# Altium schematic sheet SqTeclado.SchDoc(SqTeclado) (continued)
## Library data of the parts (continued)
R5 = ERJ-3EKF1004V: Res Thick Film 0603 1M Ohm 1% 1/10W ±100ppm/°C Molded SMD Punched Carrier T/R; Manufacturer: Panasonic; Max Power Dissipation: 100mW; Value: 1MΩ; Voltage Rating: 75V; Case Code (Imperial): 0603; Case/Package: 0603; Category: Chip SMD Resistors; China RoHS: Non-Compliant; Component Kind: Standard; ComponentLink1Description: https://sigma.octopart.com:443/138766958/technical_drawing/Panasonic-ERJ-3EKF1004V.pdf?src-supplier=Upverter; ComponentLink1URL: https://sigma.octopart.com:443/138766958/technical_drawing/Panasonic-ERJ-3EKF1004V.pdf?src-supplier=Upverter; ComponentLink2Description: https://datasheet.octopart.com:443/ERJ-3EKF1004V-Panasonic-datasheet-82288794.pdf?src-supplier=Future+Electronics; ComponentLink2URL: https://datasheet.octopart.com:443/ERJ-3EKF1004V-Panasonic-datasheet-82288794.pdf?src-supplier=Future+Electronics; ComponentLink3Description: https://datasheet.octopart.com:443/ERJ-3EKF1004V-Panasonic-datasheet-13266541.pdf?src-supplier=RS+(Formerly+Allied+Electronics); ComponentLink3URL: https://datasheet.octopart.com:443/ERJ-3EKF1004V-Panasonic-datasheet-13266541.pdf?src-supplier=RS+(Formerly+Allied+Electronics); ComponentLink4Description: https://datasheet.octopart.com:443/ERJ-3EKF1004V-Panasonic-datasheet-10025800.pdf?src-supplier=element14+APAC; ComponentLink4URL: https://datasheet.octopart.com:443/ERJ-3EKF1004V-Panasonic-datasheet-10025800.pdf?src-supplier=element14+APAC; ComponentLink5Description: https://datasheet.octopart.com:443/ERJ-3EKF1004V-Panasonic-datasheet-8853621.pdf?src-supplier=element14+APAC; ComponentLink5URL: https://datasheet.octopart.com:443/ERJ-3EKF1004V-Panasonic-datasheet-8853621.pdf?src-supplier=element14+APAC; ComponentLink6Description: https://datasheet.octopart.com:443/ERJ-3EKF1004V-Panasonic-datasheet-5313456.pdf?src-supplier=element14+APAC; ComponentLink6URL: https://datasheet.octopart.com:443/ERJ-3EKF1004V-Panasonic-datasheet-5313456.pdf?src-supplier=element14+APAC; ComponentLink7Description: https://datasheet.octopart.com:443/ERJ-3EKF1004V-Panasonic-datasheet-48891056.pdf?src-supplier=Newark; ComponentLink7URL: https://datasheet.octopart.com:443/ERJ-3EKF1004V-Panasonic-datasheet-48891056.pdf?src-supplier=Newark; ComponentLink8Description: https://datasheet.octopart.com:443/ERJ-3EKF1004V-Panasonic-datasheet-168681906.pdf?src-supplier=Newark; ComponentLink8URL: https://datasheet.octopart.com:443/ERJ-3EKF1004V-Panasonic-datasheet-168681906.pdf?src-supplier=Newark; ComponentLink9Description: https://datasheet.octopart.com:443/ERJ-3EKF1004V-Panasonic-datasheet-136608610.pdf?src-supplier=Farnell; ComponentLink9URL: https://datasheet.octopart.com:443/ERJ-3EKF1004V-Panasonic-datasheet-136608610.pdf?src-supplier=Farnell; ComponentLink10Description: https://datasheet.octopart.com:443/ERJ-3EKF1004V-Panasonic-datasheet-154766169.pdf?src-supplier=Master+Electronics; ComponentLink10URL: https://datasheet.octopart.com:443/ERJ-3EKF1004V-Panasonic-datasheet-154766169.pdf?src-supplier=Master+Electronics; ComponentLink11Description: https://datasheet.octopart.com:443/ERJ3EKF1004V-Panasonic-datasheet-138819429.pdf?src-supplier=Burklin+Elektronik; ComponentLink11URL: https://datasheet.octopart.com:443/ERJ3EKF1004V-Panasonic-datasheet-138819429.pdf?src-supplier=Burklin+Elektronik; ComponentLink12Description: https://datasheet.octopart.com/ERJ-3EKF1004V-Panasonic-datasheet-78375410.pdf; ComponentLink12URL: https://datasheet.octopart.com/ERJ-3EKF1004V-Panasonic-datasheet-78375410.pdf; ComponentLink13Description: https://datasheet.octopart.com:443/ERJ-3EKF1004V-Panasonic-datasheet-11542829.pdf?src-supplier=Arrow+Electronics; ComponentLink13URL: https://datasheet.octopart.com:443/ERJ-3EKF1004V-Panasonic-datasheet-11542829.pdf?src-supplier=Arrow+Electronics; ComponentLink14Description: https://datasheet.octopart.com:443/ERJ-3EKF1004V-Panasonic-datasheet-7538305.pdf?src-supplier=Jameco; ComponentLink14URL: https://datasheet.octopart.com:443/ERJ-3EKF1004V-Panasonic-datasheet-7538305.pdf?src-supplier=Jameco; ComponentLink15Description: https://datasheet.octopart.com:443/ERJ-3EKF1004V-Panasonic-datasheet-118602.pdf?src-supplier=DigiKey; ComponentLink15URL: https://datasheet.octopart.com:443/ERJ-3EKF1004V-Panasonic-datasheet-118602.pdf?src-supplier=DigiKey; ComponentLink16Description: https://datasheet.octopart.com:443/ERJ-3EKF1004V-Panasonic-datasheet-41391857.pdf?src-supplier=Electro+Sonic; ComponentLink16URL: https://datasheet.octopart.com:443/ERJ-3EKF1004V-Panasonic-datasheet-41391857.pdf?src-supplier=Electro+Sonic; Composition: Thick Film; Contact Plating: Tin; Depth: 800µm; Features: Automotive AEC-Q200; Height: 450µm; Lead Free: Lead Free; Length: 1.6mm; Lifecycle Status: Production; Manufacturer Part Number: ERJ-3EKF1004V; Max Operating Temperature: 155°C; Military Standard: Not; Min Operating Temperature: -55°C; Mounting Technology: Surface Mount; Number of Terminals: 2; Number of Terminations: 2; Pins: 2; Power Dissipation: 100mW; Power Rating: 100mW; Pricing: 1=0,1, 10=0,034, 25=0,0256, 50=0,021, 100=0,0173, 250=0,01364, 500=0,01158, 1000=0,00995 (USD); Radiation Hardening: No; REACH SVHC: Yes; RoHS: Compliant; Schedule B: 8533210045, 8533210045|8533210045|8533210045|8533210045|8533210045|8533210045, 8533210045|8533210045|8533210045|8533210045|8533210045|8533210045|8533210045, 8533210045|8533210045|8533210045|8533210045|8533210045|8533210045|8533210045|8533210045|8533210045; Stock: 52419; Supplier: DigiKey; Supplier Part Number: P1.00MHCT-ND; Temperature Coefficient: 100ppm/°C; Termination: SMD/SMT; Tolerance: 1%; Voltage Rating (DC): 75V; Width: 800µm; Working Voltage: 75V; Library Name: Esqlib.SchLib
R7 = M55342K06B1E50RS3: Fixed Resistor, Metal Glaze/thick Film, 0.15W, 1500ohm, 50V, 1% +/-Tol, 100ppm/Cel, Surface Mount, 0705; Manufacturer: Vishay; Max Power Dissipation: 150mW; Temperature Coefficient: 100ppm/°C; Value: 1.5kΩ; Case Code (Imperial): 0805; Case Code (Metric): 2012; Case/Package: 0705; Category: Chip SMD Resistors; China RoHS: Non-Compliant; Component Kind: Standard; ComponentLink1Description: https://datasheet.octopart.com:443/M55342K06B1E50RS3-Vishay-datasheet-159614010.pdf?src-supplier=IHS; ComponentLink1URL: https://datasheet.octopart.com:443/M55342K06B1E50RS3-Vishay-datasheet-159614010.pdf?src-supplier=IHS; ComponentLink2Description: http://sigma.octopart.com:80/rohs_statement/Vishay-company-15.pdf?src-supplier=Compliance+Statements; ComponentLink2URL: http://sigma.octopart.com:80/rohs_statement/Vishay-company-15.pdf?src-supplier=Compliance+Statements; Composition: Thick Film; Contact Plating: Lead, Tin; Depth: 1.27mm; Failure Rate: 0.01%; Features: Military; Height: 510µm; Length: 2.032mm; Lifecycle Status: Production; Manufacturer Part Number: M55342K06B1E50RS3; Max Operating Temperature: 150°C; Military Standard: MIL-PRF-55342; Min Operating Temperature: -65°C; Mounting Technology: Surface Mount; Number of Terminals: 2; Number of Terminations: 2; Packaging: Digi-Reel®; Power Dissipation: 150mW; Pricing: 1=1,42, 10=0,838, 25=0,7528, 50=0,74, 100=0,7082, 250=0,66168, 500=0,63208 (USD); Radiation Hardening: No; REACH SVHC: No; RoHS: Non-Compliant; Schedule B: 8533210045, 8533210045|8533210045|8533210045|8533210045|8533210045; Stock: 759; Supplier: DigiKey; Supplier Part Number: 541-M55342K06B1E50RS3CT-ND; Tolerance: 1%; Width: 1.27mm; Working Voltage: 50V; Library Name: Esqlib.SchLib
U1 = USB4110-GF-A: USB Connector, 16 Contact(s), Male, Right Angle, Surface Mount Terminal, Locking, Receptacle; Manufacturer: Global Connector Technology; Contact Resistance: 50mΩ; Dielectric Material: Polyethylene; Insulation Resistance: 100MΩ; Number of Contacts: 16; Number of Ports: 1; USB Standard: USB 2.0; Voltage Rating: 20V; Category: USB Connectors; China RoHS: Compliant; Component Kind: Standard; ComponentLink1Description: https://datasheet.octopart.com:443/USB4110-GF-A-Global-Connector-Technology-datasheet-166929324.pdf?src-supplier=SOS+electronic; ComponentLink1URL: https://datasheet.octopart.com:443/USB4110-GF-A-Global-Connector-Technology-datasheet-166929324.pdf?src-supplier=SOS+electronic; ComponentLink2Description: https://datasheet.octopart.com:443/USB4110-GF-A-Global-Connector-Technology-datasheet-137417970.pdf?src-supplier=Upverter; ComponentLink2URL: https://datasheet.octopart.com:443/USB4110-GF-A-Global-Connector-Technology-datasheet-137417970.pdf?src-supplier=Upverter; ComponentLink3Description: https://datasheet.octopart.com:443/USB4110-GF-A-Global-Connector-Technology-datasheet-179886649.pdf?src-supplier=TME; ComponentLink3URL: https://datasheet.octopart.com:443/USB4110-GF-A-Global-Connector-Technology-datasheet-179886649.pdf?src-supplier=TME; ComponentLink4Description: https://datasheet.octopart.com:443/USB4110-GF-A-GCT-datasheet-188869077.pdf?src-supplier=TME; ComponentLink4URL: https://datasheet.octopart.com:443/USB4110-GF-A-GCT-datasheet-188869077.pdf?src-supplier=TME; ComponentLink5Description: https://datasheet.octopart.com:443/USB4110-GF-A-GCT-datasheet-166933377.pdf?src-supplier=TME; ComponentLink5URL: https://datasheet.octopart.com:443/USB4110-GF-A-GCT-datasheet-166933377.pdf?src-supplier=TME; Contact Gender: Male; Contact Material: Gold, Nickel; Gender: Receptacle; Height: 3.46mm; Lifecycle Status: Production; Manufacturer Part Number: USB4110-GF-A; Max Operating Temperature: 85°C; Min Operating Temperature: -40°C; Number of Rows: 1; Orientation: Horizontal; Pins: 16; Pricing: 1=1,24, 10=1,049, 25=0,9836, 50=0,9364, 100=0,8916, 250=0,83576, 500=0,79584 (USD); REACH SVHC: Yes; RoHS: Compliant; Shell Finish: Nickel; Shell Material: Stainless Steel; Stock: 41559; Supplier: DigiKey; Supplier Part Number: 2073-USB4110-GF-A-1-ND; Terminal Pitch: 500µm; Library Name: Esqlib.SchLib
U2-U4 U6-U17 = 4958: Kailh Switch Sockets For Mx-Compatible Mechanical Keys - 20 Pack |Adafruit 4958; Manufacturer: Adafruit Industries; Category: Switch Accessories; Component Kind: Standard; Manufacturer Part Number: 4958; Pricing: 1=4,95 (USD); Stock: 66; Supplier: DigiKey; Supplier Part Number: 1528-4958-ND; Library Name: Esqlib.SchLib
U5 = STM32F103C8T6: MCU 32-bit STM32F1 ARM Cortex M3 RISC 64KB Flash 2.5V/3.3V 48-Pin LQFP Tray; Manufacturer: STMicroelectronics; Manufacturer Package Identifier: ai14911d; Address Bus Width: 32b; Clock Frequency: 72MHz; Clock Rate: 16MHz; Density: 512kb; EEPROM Memory Size: 0B; Interface: CAN, USB; Max Power Dissipation: 363mW; Max Supply Current: 50mA; Max Supply Voltage: 3.6V; Min Supply Voltage: 2V; Nominal Supply Current: 1.7µA; Number of A/D Converters: 2; Number of ADC Channels: 10; Number of Bits: 32; Number of I/Os: 37; Number of Interrupts: 16; Number of Programmable I/O: 48; Number of PWM Channels: 1; Number of Timers/Counters: 4; Number of USART Channels: 3; Operating Supply Voltage: 3.3V; Oscillator Type: Internal; Peripherals: DMA, I2C, POR, PWM, Temp Sensor, WDT; RAM Size: 20kB; Watchdog Timer: Yes; Case/Package: LQFP; Category: Microcontrollers; China RoHS: Compliant; Component Kind: Standard; ComponentLink1Description: https://datasheet.octopart.com:443/STM32F103C8T6-STMicroelectronics-datasheet-48026265.pdf?src-supplier=ICpick; ComponentLink1URL: https://datasheet.octopart.com:443/STM32F103C8T6-STMicroelectronics-datasheet-48026265.pdf?src-supplier=ICpick; ComponentLink2Description: https://datasheet.octopart.com:443/STM32F103C8T6-STMicroelectronics-datasheet-10330614.pdf?src-supplier=Newark; ComponentLink2URL: https://datasheet.octopart.com:443/STM32F103C8T6-STMicroelectronics-datasheet-10330614.pdf?src-supplier=Newark; ComponentLink3Description: https://datasheet.octopart.com:443/STM32F103C8T6-STMicroelectronics-datasheet-16839800.pdf?src-supplier=Newark; ComponentLink3URL: https://datasheet.octopart.com:443/STM32F103C8T6-STMicroelectronics-datasheet-16839800.pdf?src-supplier=Newark; ComponentLink4Description: https://datasheet.octopart.com:443/STM32F103C8T6-STMicroelectronics-datasheet-14363502.pdf?src-supplier=Farnell; ComponentLink4URL: https://datasheet.octopart.com:443/STM32F103C8T6-STMicroelectronics-datasheet-14363502.pdf?src-supplier=Farnell; ComponentLink5Description: https://datasheet.octopart.com:443/STM32F103C8T6-STMicroelectronics-datasheet-500013.pdf?src-supplier=Farnell; ComponentLink5URL: https://datasheet.octopart.com:443/STM32F103C8T6-STMicroelectronics-datasheet-500013.pdf?src-supplier=Farnell; ComponentLink6Description: https://datasheet.octopart.com:443/STM32F103C8T6-STMicroelectronics-datasheet-8365036.pdf?src-supplier=Farnell; ComponentLink6URL: https://datasheet.octopart.com:443/STM32F103C8T6-STMicroelectronics-datasheet-8365036.pdf?src-supplier=Farnell; ComponentLink7Description: https://datasheet.octopart.com:443/STM32F103C8T6-STMicroelectronics-datasheet-6396519.pdf?src-supplier=Verical; ComponentLink7URL: https://datasheet.octopart.com:443/STM32F103C8T6-STMicroelectronics-datasheet-6396519.pdf?src-supplier=Verical; ComponentLink8Description: https://datasheet.octopart.com:443/STM32F103C8T6-STMicroelectronics-datasheet-21407612.pdf?src-supplier=element14+APAC; ComponentLink8URL: https://datasheet.octopart.com:443/STM32F103C8T6-STMicroelectronics-datasheet-21407612.pdf?src-supplier=element14+APAC; ComponentLink9Description: https://datasheet.octopart.com:443/STM32F103C8T6-STMicroelectronics-datasheet-8700640.pdf?src-supplier=element14; ComponentLink9URL: https://datasheet.octopart.com:443/STM32F103C8T6-STMicroelectronics-datasheet-8700640.pdf?src-supplier=element14; ComponentLink10Description: https://datasheet.octopart.com:443/STM32F103C8T6-STMicroelectronics-datasheet-177520228.pdf?src-supplier=Burklin+Elektronik; ComponentLink10URL: https://datasheet.octopart.com:443/STM32F103C8T6-STMicroelectronics-datasheet-177520228.pdf?src-supplier=Burklin+Elektronik; ComponentLink11Description: https://datasheet.octopart.com:443/STM32F103C8T6-STMicroelectronics-datasheet-165583531.pdf?src-supplier=SOS+electronic; ComponentLink11URL: https://datasheet.octopart.com:443/STM32F103C8T6-STMicroelectronics-datasheet-165583531.pdf?src-supplier=SOS+electronic; ComponentLink12Description: https://datasheet.octopart.com:443/STM32F103C8T6-STMicroelectronics-datasheet-14106722.pdf?src-supplier=iiiC; ComponentLink12URL: https://datasheet.octopart.com:443/STM32F103C8T6-STMicroelectronics-datasheet-14106722.pdf?src-supplier=iiiC; ComponentLink13Description: https://datasheet.octopart.com:443/STM32F103C8T6-STMicroelectronics-datasheet-17594274.pdf?src-supplier=TME; ComponentLink13URL: https://datasheet.octopart.com:443/STM32F103C8T6-STMicroelectronics-datasheet-17594274.pdf?src-supplier=TME; ComponentLink14Description: https://datasheet.octopart.com:443/STM32F103C8T6-STMicroelectronics-datasheet-148251.pdf?src-supplier=Nu+Horizons; ComponentLink14URL: https://datasheet.octopart.com:443/STM32F103C8T6-STMicroelectronics-datasheet-148251.pdf?src-supplier=Nu+Horizons; Core Architecture: ARM; Data Bus Width: 32b; Flash Memory Size: 64kB; Frequency: 72MHz; Height: 1.45mm; Height - Seated (Max): 1.6mm; Lead Free: Lead Free; Length: 7mm; Lifecycle Status: Production; Manufacturer Part Number: STM32F103C8T6; Max Operating Temperature: 85°C; Memory Format: FLASH; Memory Size: 64kB; Min Operating Temperature: -40°C; Mounting Technology: Surface Mount; Number of Channels: 10; Number of I2C Channels: 2; Number of SPI Channels: 2; Number of Terminals: 48; Pins: 48; Pricing: 1=6,08, 10=4,662, 25=4,3084, 100=3,9192, 250=3,73372, 500=3,6219, 1000=3,5299, 2500=3,43276 (USD); Radiation Hardening: No; REACH SVHC: Yes; RoHS: Compliant; Schedule B: 8542310000; Stock: 5599; Supplier: DigiKey; Supplier Part Number: 497-6063-ND; Terminal Pitch: 500µm; Termination: SMD/SMT; Width: 7mm; Library Name: Esqlib.SchLib
U18 = USBLC6-2SC6: USBLC6 Series 2 Line 6 V Uni / Bi-Directional ESD Protection - SOT-23-6; Manufacturer: STMicroelectronics; Breakdown Voltage: 6V; Clamping Voltage: 17V; Data Rate: 480Mbps; Direction: Unidirectional; Element Configuration: Dual; ESD Protection: Yes; Forward Voltage: 1.1V; Leakage Current: 150nA; Max Junction Temperature (Tj): 125°C; Max Repetitive Reverse Voltage (Vrrm): 5.25V; Number of Bidirectional Channels: 2; Number of Elements: 1; Peak Pulse Current: 5A; Test Current: 1mA; Value: 2.5pF; Case/Package: SOT-23; Category: TVS Diodes; China RoHS: Compliant; Component Kind: Standard; ComponentLink1Description: https://datasheet.octopart.com:443/USBLC6-2SC6-STMicroelectronics-datasheet-10412581.pdf?src-supplier=Newark; ComponentLink1URL: https://datasheet.octopart.com:443/USBLC6-2SC6-STMicroelectronics-datasheet-10412581.pdf?src-supplier=Newark; ComponentLink2Description: https://datasheet.octopart.com:443/USBLC6-2SC6-STMicroelectronics-datasheet-181961833.pdf?src-supplier=Newark; ComponentLink2URL: https://datasheet.octopart.com:443/USBLC6-2SC6-STMicroelectronics-datasheet-181961833.pdf?src-supplier=Newark; ComponentLink3Description: https://datasheet.octopart.com:443/USBLC6-2SC6-STMicroelectronics-datasheet-525477.pdf?src-supplier=Factory+Futures; ComponentLink3URL: https://datasheet.octopart.com:443/USBLC6-2SC6-STMicroelectronics-datasheet-525477.pdf?src-supplier=Factory+Futures; ComponentLink4Description: https://datasheet.octopart.com:443/USBLC6-2SC6-STMicroelectronics-datasheet-82998616.pdf?src-supplier=Farnell; ComponentLink4URL: https://datasheet.octopart.com:443/USBLC6-2SC6-STMicroelectronics-datasheet-82998616.pdf?src-supplier=Farnell; ComponentLink5Description: https://datasheet.octopart.com:443/USBLC6-2SC6-STMicroelectronics-datasheet-46285.pdf?src-supplier=Farnell; ComponentLink5URL: https://datasheet.octopart.com:443/USBLC6-2SC6-STMicroelectronics-datasheet-46285.pdf?src-supplier=Farnell; ComponentLink6Description: https://datasheet.octopart.com:443/USBLC6-2SC6-STMicroelectronics-datasheet-14050643.pdf?src-supplier=iiiC; ComponentLink6URL: https://datasheet.octopart.com:443/USBLC6-2SC6-STMicroelectronics-datasheet-14050643.pdf?src-supplier=iiiC; ComponentLink7Description: https://datasheet.octopart.com:443/USBLC6-2SC6-STMicroelectronics-datasheet-10521292.pdf?src-supplier=Jameco; ComponentLink7URL: https://datasheet.octopart.com:443/USBLC6-2SC6-STMicroelectronics-datasheet-10521292.pdf?src-supplier=Jameco; Contact Plating: Tin; Depth: 1.75mm; Height: 1.45mm; Lead Free: Lead Free; Length: 3.05mm; Lifecycle Status: Production; Manufacturer Part Number: USBLC6-2SC6; Max Breakdown Voltage: 6V; Max Operating Temperature: 125°C; Max Reverse Leakage Current: 150nA; Min Breakdown Voltage: 6V; Min Operating Temperature: -40°C; Mounting Technology: Surface Mount; Number of Channels: 2; Number of Terminals: 6; Operating Supply Voltage: 1.1V; Peak Reverse Current: 150nA; Pins: 6; Polarity: Unidirectional; Power Line Protection: Yes; Pricing: 1=0,36, 10=0,243, 100=0,163, 500=0,127, 1000=0,114 (USD); Radiation Hardening: No; REACH SVHC: Yes; Reverse Breakdown Voltage: 6V; RoHS: Compliant; Schedule B: 8536300000, 8536300000|8536300000|8536300000|8536300000|8536300000|8536300000|8536300000|8536300000|8536300000|8536300000|8536300000|8536300000|8536300000|8536300000|8536300000|8536300000|8536300000|8536300000|8536300000|8536300000|8536300000|8536300000|8536300000|85; Stock: 161946; Supplier: DigiKey; Supplier Part Number: 497-5235-1-ND; Termination: SMD/SMT; Width: 1.75mm; Working Voltage: 5V; Library Name: Esqlib.SchLib
U19 = RT9193-33GB: RT9193 Series 300 mA 3.3 V Ultra-Low Noise Ultra-Fast CMOS LDO Regulator-SC-70-5; Manufacturer: Richtek; Dropout Voltage: 220mV; Max Junction Temperature (Tj): 125°C; Max Supply Voltage: 5.5V; Min Current Limit: 360mA; Min Input Voltage: 4.3V; Nominal Output Voltage: 3.3V; Number of Circuits: 1; Output Current: 300mA; Voltage Tolerance: 2%; Case/Package: SOT-23-5; Category: LDO Voltage Regulators; Component Kind: Standard; ComponentLink1Description: https://datasheet.octopart.com:443/RT9193-33GB-Richtek-datasheet-181204355.pdf?src-supplier=ODG+(Origin+Data+Global); ComponentLink1URL: https://datasheet.octopart.com:443/RT9193-33GB-Richtek-datasheet-181204355.pdf?src-supplier=ODG+(Origin+Data+Global); ComponentLink2Description: https://datasheet.octopart.com:443/RT9193-33GB-Richtek-datasheet-103962855.pdf?src-supplier=Augswan; ComponentLink2URL: https://datasheet.octopart.com:443/RT9193-33GB-Richtek-datasheet-103962855.pdf?src-supplier=Augswan; ComponentLink3Description: https://datasheet.octopart.com:443/RT9193-33GB-Richtek-datasheet-140440429.pdf?src-supplier=LKR; ComponentLink3URL: https://datasheet.octopart.com:443/RT9193-33GB-Richtek-datasheet-140440429.pdf?src-supplier=LKR; ComponentLink4Description: https://datasheet.octopart.com:443/RT9193-33GB-Richtek-datasheet-21631297.pdf?src-supplier=element14+APAC; ComponentLink4URL: https://datasheet.octopart.com:443/RT9193-33GB-Richtek-datasheet-21631297.pdf?src-supplier=element14+APAC; ComponentLink5Description: https://datasheet.octopart.com:443/RT9193-33GB-Richtek-datasheet-21405099.pdf?src-supplier=Future+Electronics; ComponentLink5URL: https://datasheet.octopart.com:443/RT9193-33GB-Richtek-datasheet-21405099.pdf?src-supplier=Future+Electronics; ComponentLink6Description: https://datasheet.octopart.com:443/RT9193-33GB-Richtek-datasheet-12517944.pdf?src-supplier=Future+Electronics; ComponentLink6URL: https://datasheet.octopart.com:443/RT9193-33GB-Richtek-datasheet-12517944.pdf?src-supplier=Future+Electronics; ComponentLink7Description: https://datasheet.octopart.com:443/RT9193-33GB-Richtek-datasheet-14171959.pdf?src-supplier=iiiC; ComponentLink7URL: https://datasheet.octopart.com:443/RT9193-33GB-Richtek-datasheet-14171959.pdf?src-supplier=iiiC; Height - Seated (Max): 1.295mm; Lead Free: Lead Free; Length: 2.89mm; Lifecycle Status: Production; Manufacturer Part Number: RT9193-33GB; Max Operating Temperature: 85°C; Min Operating Temperature: -40°C; Mounting Technology: Surface Mount; Number of Outputs: 1; Number of Regulators: 1; Number of Terminals: 5; Output Voltage: 3.3V; Pins: 5; Pricing: 1=0,41, 10=0,283, 25=0,2516, 100=0,2178, 250=0,20156, 500=0,19182, 1000=0,18377 (USD); REACH SVHC: Yes; RoHS: Compliant; Stock: 9476; Supplier: DigiKey; Supplier Part Number: 1028-1014-1-ND; Terminal Pitch: 950µm; Width: 1.6mm; Library Name: Esqlib.SchLib
X1-X15 = MX1A-C1NN: Switch, Key, Spst-no, Keyboard, Low Profile, 10MA, 12VDC, Soft Tactile, Fp Mnt, Std Force; Manufacturer: Cherry; Actuator Length: 3.6mm; Actuator Material: Polyethylene, Thermoplastic; Contact Resistance: 200mΩ; Contact Voltage Rating (AC): 12V; Dielectric Material: Thermoplastic; Electrical Life: 20Mcycles; Insulation Resistance: 100MΩ; Operating Force: 589.4mN; Row Spacing: 2.54mm; Switch Function: Off-Mom; Throw Configuration: SPST; Category: Pushbutton Switches; Component Kind: Standard; ComponentLink1Description: https://datasheet.octopart.com:443/MX1A-C1NN-Cherry-datasheet-160429.pdf?src-supplier=Electro+Sonic; ComponentLink1URL: https://datasheet.octopart.com:443/MX1A-C1NN-Cherry-datasheet-160429.pdf?src-supplier=Electro+Sonic; ComponentLink2Description: https://datasheet.octopart.com:443/MX1A-C1NN-Cherry-datasheet-11735291.pdf?src-supplier=Electro+Sonic; ComponentLink2URL: https://datasheet.octopart.com:443/MX1A-C1NN-Cherry-datasheet-11735291.pdf?src-supplier=Electro+Sonic; ComponentLink3Description: https://datasheet.octopart.com:443/MX1A-C1NN-Cherry-datasheet-130429.pdf?src-supplier=Newark; ComponentLink3URL: https://datasheet.octopart.com:443/MX1A-C1NN-Cherry-datasheet-130429.pdf?src-supplier=Newark; ComponentLink4Description: https://datasheet.octopart.com:443/MX1A-C1NN-Cherry-datasheet-101570275.pdf?src-supplier=Newark; ComponentLink4URL: https://datasheet.octopart.com:443/MX1A-C1NN-Cherry-datasheet-101570275.pdf?src-supplier=Newark; ComponentLink5Description: https://datasheet.octopart.com:443/MX1A-C1NN-Cherry-datasheet-5391353.pdf?src-supplier=Newark; ComponentLink5URL: https://datasheet.octopart.com:443/MX1A-C1NN-Cherry-datasheet-5391353.pdf?src-supplier=Newark; ComponentLink6Description: https://datasheet.octopart.com:443/MX1AC1NN-Cherry-datasheet-137317204.pdf?src-supplier=Sager+Electronics; ComponentLink6URL: https://datasheet.octopart.com:443/MX1AC1NN-Cherry-datasheet-137317204.pdf?src-supplier=Sager+Electronics; Contact Current Rating: 10mA; Contact Material: Gold, Silver; Contact Plating: Gold; Current Rating: 10mA; Housing Material: Thermoplastic; Lead Free: Lead Free; Length: 15.5956mm; Manufacturer Part Number: MX1A-C1NN; Max Current Rating: 10mA; Max Operating Temperature: 70°C; Max Voltage Rating (AC): 12V; Max Voltage Rating (DC): 12V; Mechanical Life: 20Mcycles; Min Operating Temperature: -10°C; Mounting Technology: Front, Panel, Through Hole; Number of Terminals: 2; Packaging: Bulk; Pricing: 1=1,25 (USD); REACH SVHC: Yes; RoHS: Compliant; Stock: 664; Supplier: DigiKey; Supplier Part Number: CH198-ND; Terminal Pitch: 6.35mm; Termination: PC Pin; Voltage Rating (AC): 12V; Voltage Rating (DC): 12V; Width: 15.6mm; Library Name: Esqlib.SchLib
Y1 = ECS-80-20-5PXDU-TR: Crystal 8MHz ±30ppm (Tol) ±100ppm (Stability) 20pF FUND 60Ohm 2-Pin SMD T/R; Manufacturer: ECS International; ESR: 60Ω; Frequency Tolerance: 0.003%; Category: Crystals; China RoHS: Compliant; Component Kind: Standard; ComponentLink1Description: https://datasheet.octopart.com:443/ECS-80-20-5PXDU-TR-ECS-International-datasheet-17018830.pdf?src-supplier=IHS; ComponentLink1URL: https://datasheet.octopart.com:443/ECS-80-20-5PXDU-TR-ECS-International-datasheet-17018830.pdf?src-supplier=IHS; ComponentLink2Description: https://datasheet.octopart.com:443/ECS-80-20-5PXDU-TR-ECS-International-datasheet-166511516.pdf?src-supplier=Master+Electronics; ComponentLink2URL: https://datasheet.octopart.com:443/ECS-80-20-5PXDU-TR-ECS-International-datasheet-166511516.pdf?src-supplier=Master+Electronics; ComponentLink3Description: https://datasheet.octopart.com:443/ECS-80-20-5PXDU-TR-ECS-International-datasheet-14433430.pdf?src-supplier=Factory+Futures; ComponentLink3URL: https://datasheet.octopart.com:443/ECS-80-20-5PXDU-TR-ECS-International-datasheet-14433430.pdf?src-supplier=Factory+Futures; ComponentLink4Description: https://datasheet.octopart.com:443/ECS-80-20-5PXDU-TR-ECS-International-datasheet-17018642.pdf?src-supplier=Future+Electronics; ComponentLink4URL: https://datasheet.octopart.com:443/ECS-80-20-5PXDU-TR-ECS-International-datasheet-17018642.pdf?src-supplier=Future+Electronics; ComponentLink5Description: https://datasheet.octopart.com:443/ECS-80-20-5PXDU-TR-ECS-datasheet-23531.pdf?src-supplier=Mouser; ComponentLink5URL: https://datasheet.octopart.com:443/ECS-80-20-5PXDU-TR-ECS-datasheet-23531.pdf?src-supplier=Mouser; ESR (Equivalent Series Resistance): 60Ω; Frequency: 8MHz; Frequency Stability: 100ppm; Height: 4.2926mm; Lead Free: Lead Free; Length: 11.4046mm; Lifecycle Status: Production; Load Capacitance: 20pF; Manufacturer Part Number: ECS-80-20-5PXDU-TR; Max Operating Temperature: 125°C; Min Operating Temperature: -55°C; Mounting Technology: Surface Mount; Packaging: Cut Tape (CT); Pricing: 1=0,44, 10=0,384, 25=0,3632, 50=0,3482, 100=0,3337, 250=0,31564, 500=0,30256 (USD); REACH SVHC: Yes; RoHS: Compliant; Schedule B: 8541600025, 8541600025|8541600025, 8541600025|8541600025|8541600025, 8541600025|8541600025|8541600025|8541600025; Stock: 26910; Supplier: DigiKey; Supplier Part Number: XC1526CT-ND; Termination: SMD/SMT; Tolerance: 0.003%; Width: 4.8006mm; Library Name: Esqlib.SchLib
